# Altium schematic sheet Power_6V.SchDoc(Power_6V)
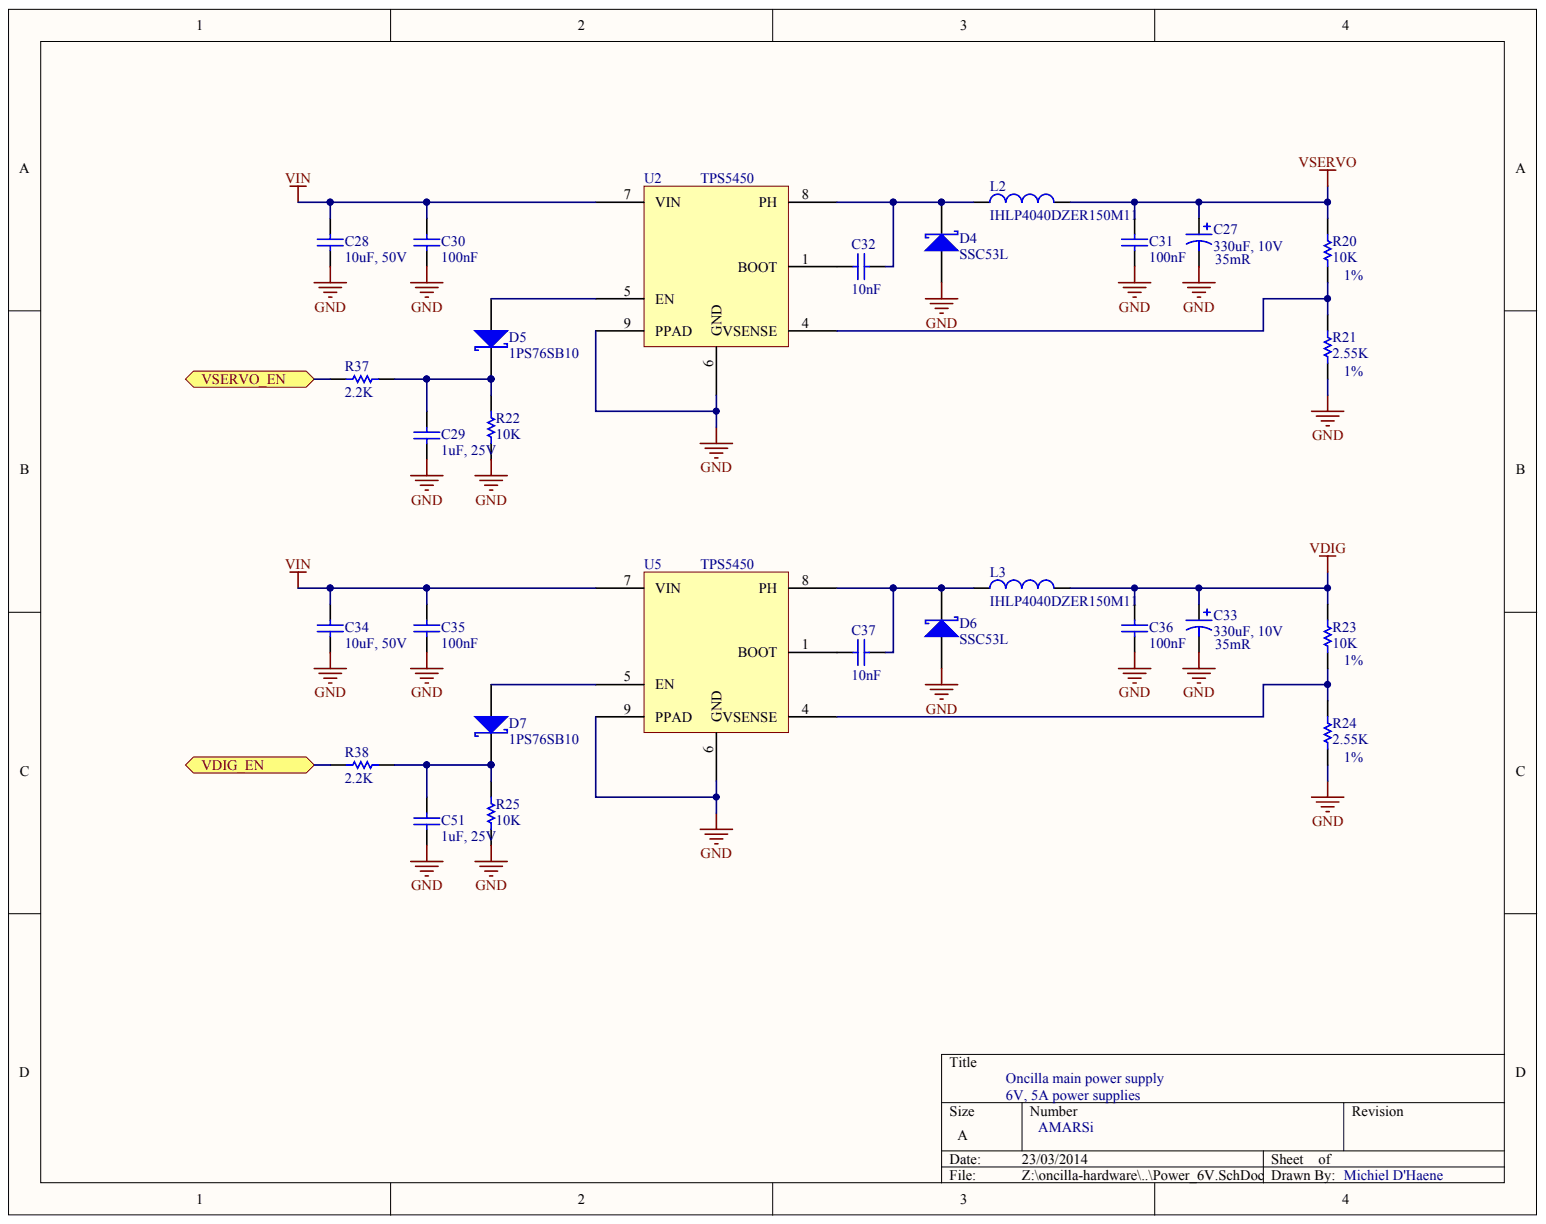
[diagram p0: Power_6V.SchDoc]

## Parts
C27  330uF, 10V  ESR: 35mR
C28  10uF, 50V
C29  1uF, 25V
C30  100nF
C31  100nF
C32  10nF
C33  330uF, 10V  ESR: 35mR
C34  10uF, 50V
C35  100nF
C36  100nF
C37  10nF
C51  1uF, 25V
D4  SSC53L
D5  1PS76SB10
D6  SSC53L
D7  1PS76SB10
L2  IHLP4040DZER150M11
L3  IHLP4040DZER150M11
R20  value 10K  Precision: 1%  (hidden comment: 10k, 1%)
R21  value 2.55K  Precision: 1%  (hidden comment: 2.55k, 1%)
R22  10k
R23  value 10K  Precision: 1%  (hidden comment: 10k, 1%)
R24  value 2.55K  Precision: 1%  (hidden comment: 2.55k, 1%)
R25  10k
R37  2.2k
R38  2.2k
U2  TPS5450
U5  TPS5450

## Ports
VDIG_EN, VSERVO_EN

## Nets
GND: C27.2 C28.1 C29.1 C30.1 C31.1 C33.2 C34.1 C35.1 C36.1 C51.1 D4.1 D6.1 R21.1 R22.1 R24.1 R25.1 U2.6(GND) U2.9(PPAD) U5.6(GND) U5.9(PPAD)
VDIG: C33.1 C36.2 L3.2 R23.2
VIN: C28.2 C30.2 C34.2 C35.2 U2.7(VIN) U5.7(VIN)
VSERVO: C27.1 C31.2 L2.2 R20.2
VDIG_EN: R38.2 ; port VDIG_EN
VSERVO_EN: R37.2 ; port VSERVO_EN
NetC29_2: C29.2 D5.1 R22.2 R37.1
NetC32_1: C32.1 U2.1(BOOT)
NetC32_2: C32.2 D4.2 L2.1 U2.8(PH)
NetC37_1: C37.1 U5.1(BOOT)
NetC37_2: C37.2 D6.2 L3.1 U5.8(PH)
NetC51_2: C51.2 D7.1 R25.2 R38.1
NetD5_2: D5.2 U2.5(EN)
NetD7_2: D7.2 U5.5(EN)
NetR20_1: R20.1 R21.2 U2.4(VSENSE)
NetR23_1: R23.1 R24.2 U5.4(VSENSE)

## Library data of the parts
C27 C33 = (no symbol name): Note: See Data Sheet for full range of components; Published: 19-Mar-2004; DatasheetDocument: 04-Feb-2003; PackageReference: 7343; Code_JEITA: 7343; ComponentLink1Description: Manufacturer Link; ComponentLink1URL: http://www.vishay.com/; ComponentLink2Description: Datasheet; ComponentLink2URL: http://www.vishay.com/docs/40052/255d.pdf; Value: 330uF, 10V; Publisher: Altium Limited
R22 R25 R37 R38 = (no symbol name): Precision: 5%; Published: 8-Jun-2000; LatestRevisionDate: 17-Jul-2002; LatestRevisionNote: Re-released for DXP Platform.; PackageReference: AXIAL-0.5; Publisher: Altium Limited
  R22 R25: Value: 10K
  R37 R38: Value: 2.2K
C28-C32 C34-C37 C51 D4-D7 L2 L3 R20 R21 R23 R24 U2 U5 = (no symbol name)
  C28 C34: Published: 8-Jun-2000; LatestRevisionDate: 29-May-2009; LatestRevisionNote: IPC-7351 Footprint Added.; PackageReference: C1206; PackageDescription: Chip Capacitor; Value: 10uF, 50V
  C29 C51: Published: 8-Jun-2000; LatestRevisionDate: 29-May-2009; LatestRevisionNote: IPC-7351 Footprint Added.; PackageReference: C1206; PackageDescription: Chip Capacitor; Value: 1uF, 25V
  C30 C31 C35 C36: Published: 8-Jun-2000; LatestRevisionDate: 29-May-2009; LatestRevisionNote: IPC-7351 Footprint Added.; PackageReference: C1206; PackageDescription: Chip Capacitor; Value: 100nF
  C32 C37: Published: 8-Jun-2000; LatestRevisionDate: 29-May-2009; LatestRevisionNote: IPC-7351 Footprint Added.; PackageReference: C1206; PackageDescription: Chip Capacitor; Value: 10nF
  D4 D6: Published: 8-Jun-2000; LatestRevisionDate: 17-Jul-2002; LatestRevisionNote: Re-released for DXP Platform.; DatasheetDocument: PD-2.439; PackageReference: SMC; Code_JEDEC: DO-214-AB; ComponentLink1Description: Manufacturer Link; ComponentLink1URL: http://www.irf.com/; ComponentLink2Description: Datasheet; ComponentLink2URL: http://www.irf.com/product-info/datasheets/data/30bq040.pdf
  D5 D7: Published: 17-Sep-2003; LatestRevisionDate: 19-Mar-2004; LatestRevisionNote: Silkscreen size reduced.; DatasheetVersion: 14-Oct-1996; PackageVersion: May-1999; PackageReference: SOD323; PackageDescription: SOD-323/SC-76; 2 Leads; Body 1.7 x 1.3 mm, (LxW); ComponentLink1Description: Manufacturer Link; ComponentLink1URL: http://www.semiconductors.philips.com/; ComponentLink2Description: Datasheet; ComponentLink2URL: http://www.semiconductors.philips.com/acrobat/datasheets/1PS76SB10_2.pdf
  R20 R21 R23 R24: Published: 8-Jun-2000; LatestRevisionDate: 17-Jul-2002; LatestRevisionNote: Re-released for DXP Platform.; PackageReference: AXIAL-0.5
  C28-C32 C34-C37 C51 D4-D7 R20 R21 R23 R24: Publisher: Altium Limited
